# Altium schematic sheet Programmer_Interface.SchDoc
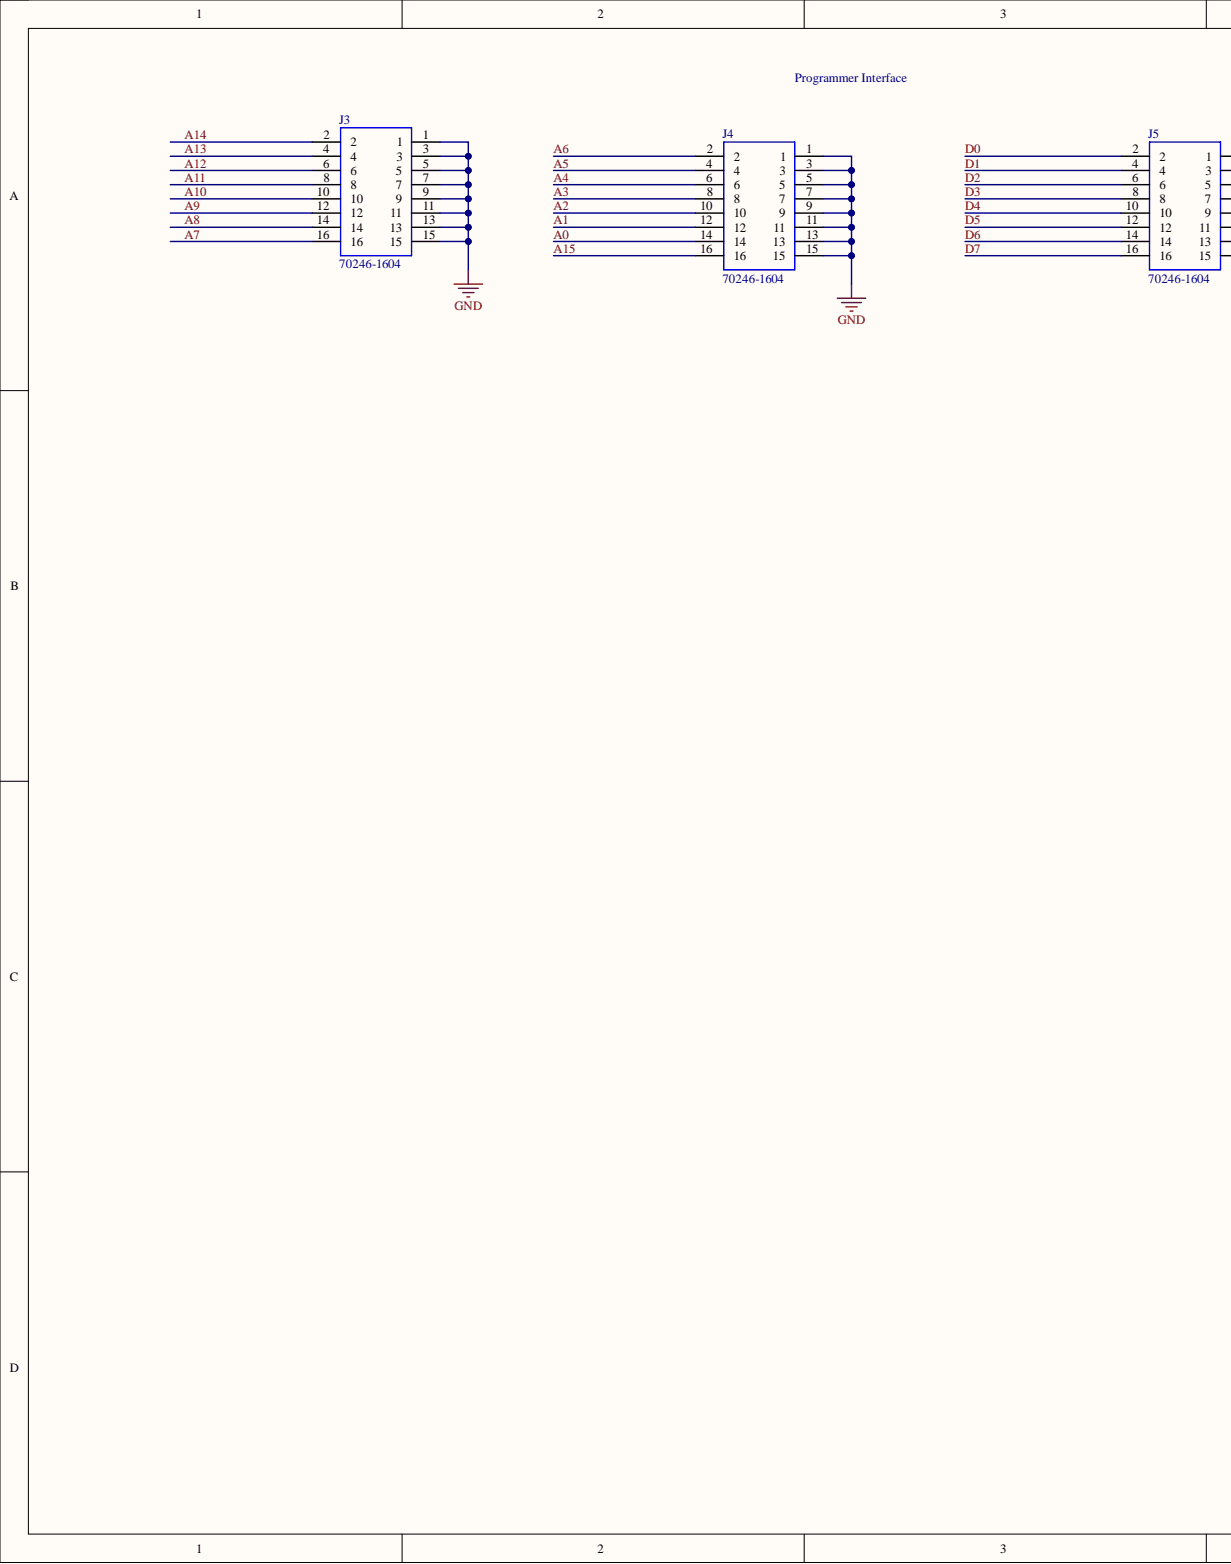
[diagram p0: Programmer_Interface.SchDoc, left]
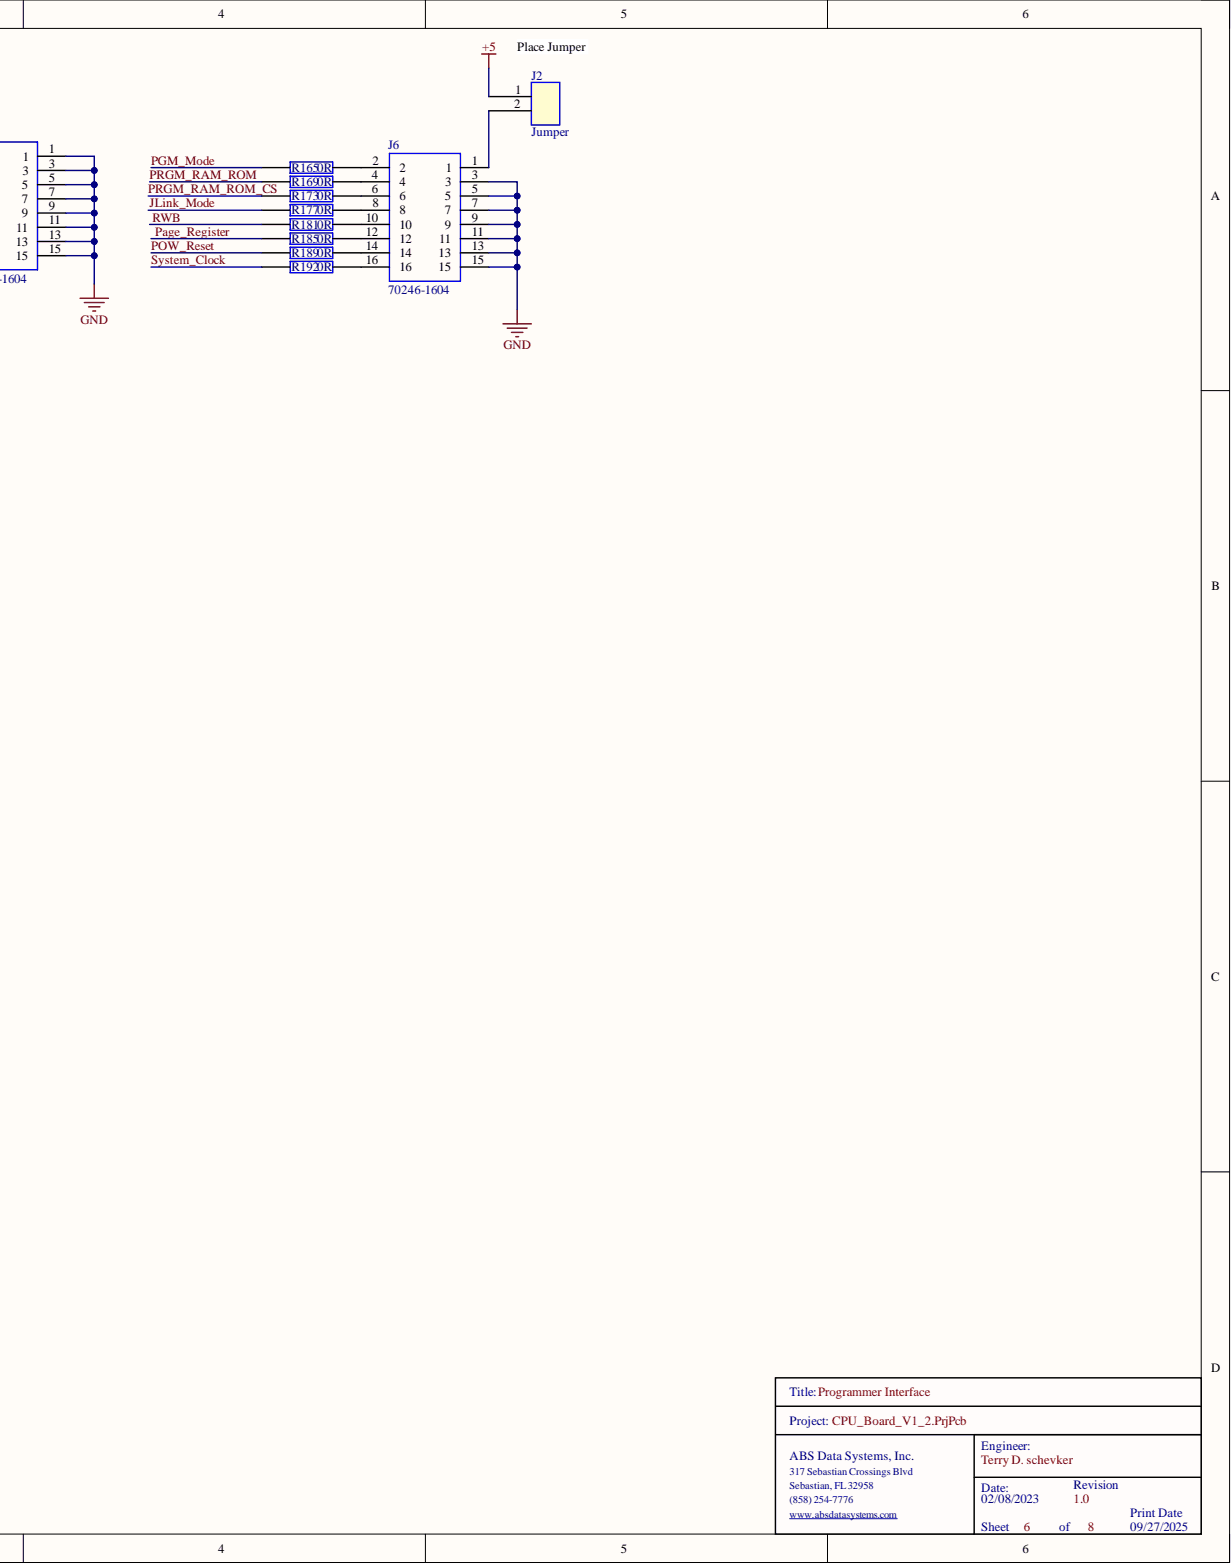
[diagram p1: Programmer_Interface.SchDoc, right]

## Parts
J2  Jumper  [Jumper]
J3  70246-1604  [SHDR16W99P254_2X8_2794X889X927P]
J4  70246-1604  [SHDR16W99P254_2X8_2794X889X927P]
J5  70246-1604  [SHDR16W99P254_2X8_2794X889X927P]
J6  70246-1604  [SHDR16W99P254_2X8_2794X889X927P]
R165  0R  [0603]  (value not drawn)
R169  0R  [0603]  (value not drawn)
R173  0R  [0603]  (value not drawn)
R177  0R  [0603]  (value not drawn)
R181  0R  [0603]  (value not drawn)
R185  0R  [0603]  (value not drawn)
R189  0R  [0603]  (value not drawn)
R192  0R  [0603]  (value not drawn)

## Nets
+5: J2.1
GND: J3.1 J3.3 J3.5 J3.7 J3.9 J3.11 J3.13 J3.15 J4.1 J4.3 J4.5 J4.7 J4.9 J4.11 J4.13 J4.15 J5.1 J5.3 J5.5 J5.7 J5.9 J5.11 J5.13 J5.15 J6.3 J6.5 J6.7 J6.9 J6.11 J6.13 J6.15
A0: J4.14
A1: J4.12
A2: J4.10
A3: J4.8
A4: J4.6
A5: J4.4
A6: J4.2
A7: J3.16
A8: J3.14
A9: J3.12
A10: J3.10
A11: J3.8
A12: J3.6
A13: J3.4
A14: J3.2
A15: J4.16
D0: J5.2
D1: J5.4
D2: J5.6
D3: J5.8
D4: J5.10
D5: J5.12
D6: J5.14
D7: J5.16
JLink_Mode: R177.1
Page_Register: R185.1
PGM_Mode: R165.1
POW_Reset: R189.1
PRGM_RAM_ROM: R169.1
PRGM_RAM_ROM_CS: R173.1
RWB: R181.1
System_Clock: R192.1
NetJ2_2: J2.2 J6.1
NetJ6_2: J6.2 R165.2
NetJ6_4: J6.4 R169.2
NetJ6_6: J6.6 R173.2
NetJ6_8: J6.8 R177.2
NetJ6_10: J6.10 R181.2
NetJ6_12: J6.12 R185.2
NetJ6_14: J6.14 R189.2
NetJ6_16: J6.16 R192.2

## Library data of the parts
J2 = Jumper: 16 Pin 3x8 Through Hold Jumprts; Manufacturer: Samtec; Manufacturer Part Number: TST-108-01-G-D; Connector Type: Male Pin; Gender: Male; Number of Contacts: 16; Pitch: 2.54mm; Row Spacing: 0.1inch; Category: Headers and Wire Housings; Color: Black; Component Kind: Standard; ComponentLink1Description: https://datasheet.ciiva.com/739/tst-mkt-739845.pdf?src-supplier=Digi-Key; ComponentLink1URL: https://datasheet.ciiva.com/739/tst-mkt-739845.pdf?src-supplier=Digi-Key; ComponentLink2Description: https://datasheet.ciiva.com/10018/htst-10018510.pdf?src-supplier=Avnet; ComponentLink2URL: https://datasheet.ciiva.com/10018/htst-10018510.pdf?src-supplier=Avnet; Contact Material: Bronze; Contact Mating Length: 0.25inch; Contact Plating: Gold; Depth: 9.27mm; Housing Color: Black; Housing Material: Polyester; Length: 27.94mm; Library Name: Misc.SchLib; Max Operating Temperature: 125°C; Min Operating Temperature: -55°C; Mount: Through Hole; Number of Positions: 16; Number of Rows: 2; Orientation: Straight; Packaging: Rail/Tube; Pricing 1: 1=2.85000, 10=2.73600, 100=2.28000, 500=1.93800, 1000=1.65300, 5000=1.48200 (USD); REACH SVHC: No SVHC; RoHS: Yes; Stock 1: 227; Supplier 1: Digi-Key; Termination: Solder
J3-J6 = 70246-1604: Molex C-GRID 70246, 2.54mm Pitch, 16 Way, 2 Row, Straight PCB Header, Through Hole; Geometry.Height: 9.27mm; Component Kind: Standard; Datasheet Link: https://www.molex.com/pdm_docs/sd/702461604_sd.pdf; Library Name: Connectors.SchLib; Manufacturer_Name: Molex; Manufacturer_Part_Number: 70246-1604; Mouser Part Number: 538-70246-1604; Mouser Price/Stock: https://www.mouser.co.uk/ProductDetail/Molex/70246-1604?qs=KUIzHt%2Fe91njhb67Cjmalw%3D%3D
R165 R169 R173 R177 R181 R185 R189 R192 = RC0603JR-070RL: YAGEO 0603 0 ohm; Manufacturer_Name: KEMET; Geometry.Height: 0.55mm; Component Kind: Standard; Datasheet Link: https://datasheet.datasheetarchive.com/originals/distributors/Datasheets_SAMA/902f9e387b938f871d31120f5fc1d65e.pdf; Library Name: Resistors.SchLib; Manufacturer_Part_Number: RC0603JR-070RL; Mouser Part Number: 603-RC0603JR-070RL; Mouser Price/Stock: https://www.mouser.co.uk/ProductDetail/YAGEO/RC0603JR-070RL?qs=2cAdsCoAWRGWyPcuXgGiXQ%3D%3D
